# Altium schematic sheet POWER_CONNECTORS.SchDoc(POWER_CONNECTORS)
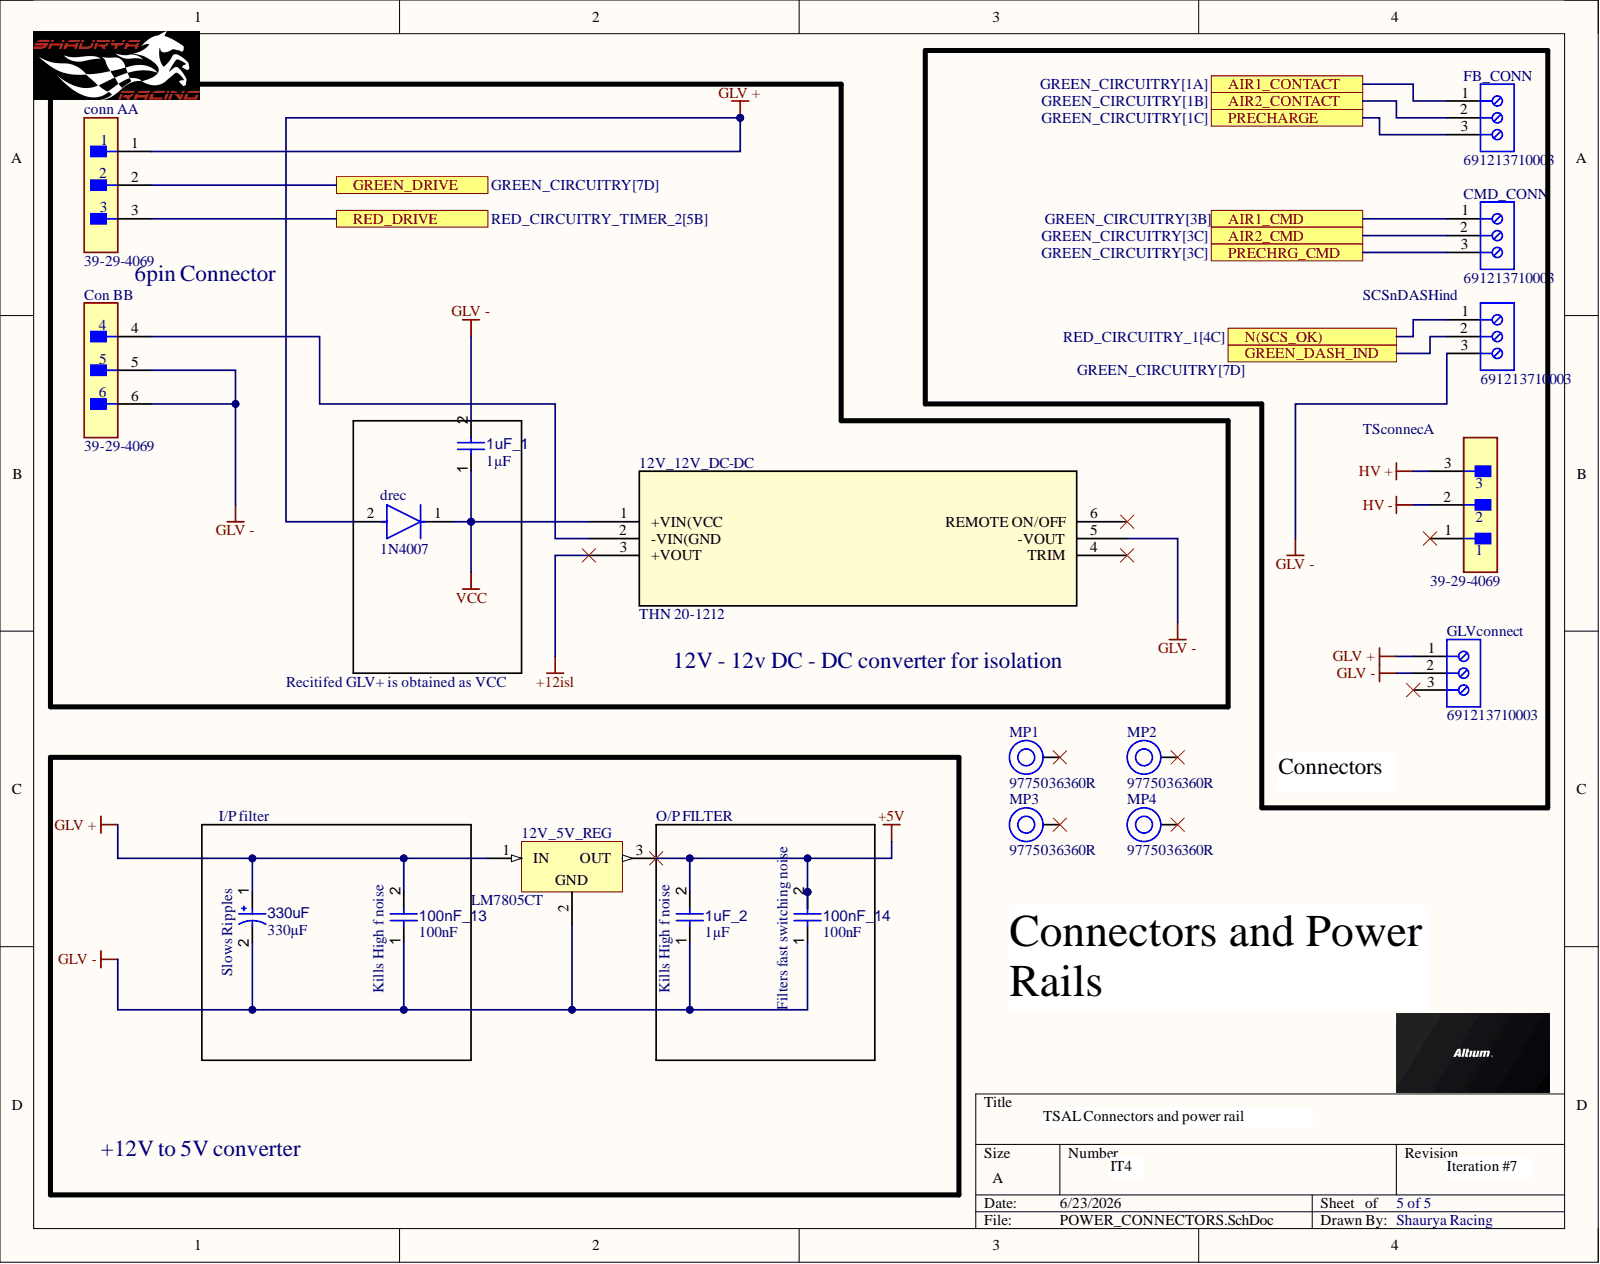
[diagram p0: POWER_CONNECTORS.SchDoc]

## Parts
1uF_1  value 1µF  (hidden comment: Capacitor 1µF +/-20% 10V 0402)
1uF_2  value 1µF  (hidden comment: Capacitor 1µF +/-20% 10V 0402)
12V_5V_REG  LM7805CT
12V_12V_DC-DC  THN 20-1212
100nF_13  value 100nF  (hidden comment: Capacitor 0.1 uF +/- 5% 16 V 0402)
100nF_14  value 100nF  (hidden comment: Capacitor 0.1 uF +/- 5% 16 V 0402)
330uF  value 330µF  (hidden comment: Capacitor 330µF +/-20% 6.3V D3)
CMD_CONN  691213710003
Con_B  39-29-4069  (part B)
conn_A  39-29-4069  (part A)
drec  1N4007
FB_CONN  691213710003
GLVconnect  691213710003
MP1  9775036360R
MP2  9775036360R
MP3  9775036360R
MP4  9775036360R
SCSnDASHind  691213710003
TSconnec  39-29-4069  (part A)

## Ports
AIR1_CMD, AIR1_CONTACT, AIR2_CMD, AIR2_CONTACT, GREEN_DASH_IND, GREEN_DRIVE, N(SCS_OK), PRECHARGE, PRECHRG_CMD, RED_DRIVE

## Nets
+5V: 1uF_2.2 12V_5V_REG.3 100nF_14.2
+12isl: 12V_12V_DC-DC.3(+VOUT)
GLV +: 12V_5V_REG.1 100nF_13.2 330uF.1 conn_A.1 drec.2 GLVconnect.1
GLV -: 1uF_1.2 1uF_2.1 12V_5V_REG.2 12V_12V_DC-DC.5(-VOUT) 100nF_13.1 100nF_14.1 330uF.2 Con_B.5 Con_B.6 GLVconnect.2 SCSnDASHind.3
HV +: TSconnec.3
HV -: TSconnec.2
VCC: 1uF_1.1 12V_12V_DC-DC.1(+VIN(VCC) drec.1
AIR1_CMD: CMD_CONN.1 ; port AIR1_CMD
AIR1_CONTACT: FB_CONN.1 ; port AIR1_CONTACT
AIR2_CMD: CMD_CONN.2 ; port AIR2_CMD
AIR2_CONTACT: FB_CONN.2 ; port AIR2_CONTACT
GREEN_DASH_IND: SCSnDASHind.2 ; port GREEN_DASH_IND
GREEN_DRIVE: conn_A.2 ; port GREEN_DRIVE
N(SCS_OK): SCSnDASHind.1 ; port N(SCS_OK)
PRECHARGE: FB_CONN.3 ; port PRECHARGE
PRECHRG_CMD: CMD_CONN.3 ; port PRECHRG_CMD
RED_DRIVE: conn_A.3 ; port RED_DRIVE
Net12V_12V_DC-DC_2: 12V_12V_DC-DC.2(-VIN(GND) Con_B.4

Not connected: 12V_12V_DC-DC 4(TRIM) 6(REMOTE_ON/OFF); GLVconnect 3; MP1 1; MP2 1; MP3 1; MP4 1; TSconnec 1

## Library data of the parts
1uF_1 1uF_2 = CMP-001-00063-3: Chip Capacitor, 1µF +/-20%, 10V, 0402, Thickness 0.6 mm; Tolerance: 10%; Case/Package: 0402; Component Kind: Standard; Max Operating Temperature: 85°C; Min Operating Temperature: -55°C; Mounting Technology: SMT; Pins: 2; Voltage Rating: 10V; Library Name: APAC - VIT, Chennai - Shaurya Racing
12V_5V_REG = CMP-2000-04938-1: Positive Voltage Regulator, 5 V, 1 A, -40 to 125 degC, 3-Pin TO-220, RoHS, Tube; Manufacturer: FairchildSemiconductor; Accuracy: ±4%; Line Regulation: 100mV; Maximum Input Voltage: 35V; Maximum Output Current: 2.2(Typ)A; Maximum Quiescent Current: 8mA; Number of Outputs: 1; Output Type: Fixed; Output Voltage: 5V; Rad Hard: No; Typical Dropout Voltage @ Current: 2@1AV; Category: LinearRegulator; Component Kind: Standard; Datasheet URL: https://datasheet.ciiva.com/26904/lm7805ct-26904988.pdf; Datasheet Version: Aug-12; Library Name: Altium Content Vault; Load Regulation: 100mV; Manufacturer URL: https://www.fairchildsemi.com/; Mounting: ThroughHole; Operating Temperature: -40to125degC; Package: 3TO-220AB; Package Description: 3-Pin Through Hole Device, Body 10 x 4.5 mm, Pitch 2.54 mm; Package Reference: TO-220; Package URL: https://www.fairchildsemi.com/package-drawings/TO/TO220B03.pdf; Packaging: Rail/Tube; Polarity: Positive; Type: Linear
12V_12V_DC-DC = CMP-01539-55433698-1: THN 20-1212 Module DC-DC 12VIN 1-OUT 12V 1.67A 20W 6-Pin; Efficiency: 89%; Isolation Voltage: 1.5kV; Max Input Voltage: 18V; Min Input Voltage: 9V; Number of Outputs: 1; Case/Package: Metal; Component Kind: Standard; Current - Output 1: 1.67A; Depth: 25.4mm; Height: 9.9mm; Length: 25.4mm; Library Name: Altium Content Vault; Max Input Voltage (DC): 18V; Max Operating Temperature: 85°C; Max Output Current: 1.67A; Max Output Power: 20W; Min Input Voltage (DC): 9V; Min Operating Temperature: -40°C; Mount: Through Hole; NexarPartId: 55433698; Nominal Input Voltage: 12V; Nominal Output Voltage: 12V; Output Current: 1.67A; Output Voltage: 12V; REACH SVHC: No SVHC; RoHS: Compliant; Voltage - Output 1: 12V; Width: 25.4mm
100nF_13 100nF_14 = CMP-001-00001-6: Chip Capacitor, 0.1 uF, +/- 5%, 16 V, 0402 (1005 Metric); Capacitor Type: Ceramic; Voltage Rating: 16V; Case/Package: 0402; Component Kind: Standard; Max Operating Temperature: 125°C; Min Operating Temperature: -55°C; Mounting Technology: SM; Pins: 2; RoHS Compliant: True; Tolerance: 5%; Library Name: APAC - VIT, Chennai - Shaurya Racing
330uF = CMP-001-00058-3: Molded Tantalum Polymer Capacitor, 330 uF, +/- 20%, 6.3 V, -55 to 105 degC, 2-Pin SMD, RoHS, Tape and Reel; Case/Package: 1210; Component Kind: Standard; Max Operating Temperature: 105°C; Min Operating Temperature: -55°C; Mounting Technology: SMT; Pins: 2; Tolerance: 20%; Voltage Rating: 6.3V; Library Name: APAC - VIT, Chennai - Shaurya Racing
CMD_CONN FB_CONN GLVconnect SCSnDASHind = CMP-1502-02527-3: 213 Series 5MM Pitch Straight, Pcb Terminal Block, Through Hole, 3 Way; Connector Type: PCB, Terminal Block; Current: 10A; Number of Contacts: 3; Number of Levels: 1; Pitch: 5.0038mm; Plating: Brass, Steel; Stripping Length: -7mm; Voltage: 300V; Wire Gauge (Max): 26AWG; Wire Gauge (Min): 16AWG; Color: Green; Component Kind: Standard; Contact Material: Brass; Contact Plating: Tin; Height: 10mm; Housing Material: Nylon; Lead Pitch: 5mm; Length: 8.3mm; Library Name: Altium Content Vault; Max Operating Temperature: 105°C; Min Operating Temperature: -40°C; Mount: Through Hole; Number of Pins: 3; Number of Positions Per Level: 3; Packaging: Box; REACH SVHC: Unknown; RoHS: Compliant; Termination: Screw; Width: 15.6mm; Wire/Cable Gauge: 16AWG
Con_B conn_A TSconnec = CMP-00257-06821913-1: 39-29-4069 Conn Power HDR 6 POS 4.2mm Solder RA Thru-Hole 6 Terminal 1 Port Mini-Fit Jr™ Bag; Manufacturer Lifecycle Status: ACTIVE; Connector Type: Male Pin; Gender: Male; Number of Circuits: 6; Number of Ports: 1; Plating: Tin; Row Spacing: 4.191mm; Color: Natural; Component Kind: Standard; Contact Material: Brass; Contact Plating: Gold; Country of Origin: US; Depth: 19.4mm; Fastening Type: Ramp; Housing Material: Nylon; Length: 23.8mm; Library Name: Altium Content Vault; Lifecycle Status: Production; Max Operating Temperature: 105°C; Min Operating Temperature: -40°C; Mount: Through Hole; NexarPartId: 6821913; Number of Contacts: 6; Number of Positions: 6; Number of Rows: 2; Number of Terminals: 6; Orientation: Right Angle; Packaging: Bulk; Pitch: 4.2mm; RoHS: Compliant; Termination: Solder
drec = CMP-123542-000001-1: Rectifier diode 1000 V 1 A DO-41, ,; Manufacturer: Diotec; Capacitance: 15pF; Forward Current: 1A; Max Junction Temp: +175°C; Max Repetitive Reverse Voltage: 1000V; Max Surge Forward Current: 27A; Peak Reverse Current: 5uA; Value: 15pF; Automotive: No; Category: Diode; Component Kind: Standard; ComponentLink2Description: Datasheet; ComponentLink2URL: https://datasheet.octopart.com/1N4007-Diotec-datasheet-62346875.pdf; Device Class L1: Discrete Semiconductors; Device Class L2: Diodes; Device Class L3: Rectifier Diodes; Height: 2.8mm; Ipc Land Pattern Name: DIOAD888W100L520D280; Lead Free: Yes; Library Name: Altium Content Vault; Manufacturer Part Number: 1N4007; Octopart: https://octopart.com/1n4007-diotec-4040411; Package: 1N4007; Reverse Voltage: 1000V; RoHS: TRUE; Temperature: -50°C to +175°C; Temperature Range High: +175°C; Temperature Range Low: -50°C
MP1-MP4 = CMP-03913-78872569-2: WA-SMSR SMT Steel Spacer, Reverse M3 non Stop; Pin Length: 3.6mm; Component Kind: Standard; Length: 3.6mm; Library Name: Altium Content Vault; Material: Steel; Max Operating Temperature: 150°C; Min Operating Temperature: -55°C; Packaging: Tape and Reel; REACH SVHC: No; RoHS: Compliant
